# Altium schematic sheet p05_leds_switches.SchDoc
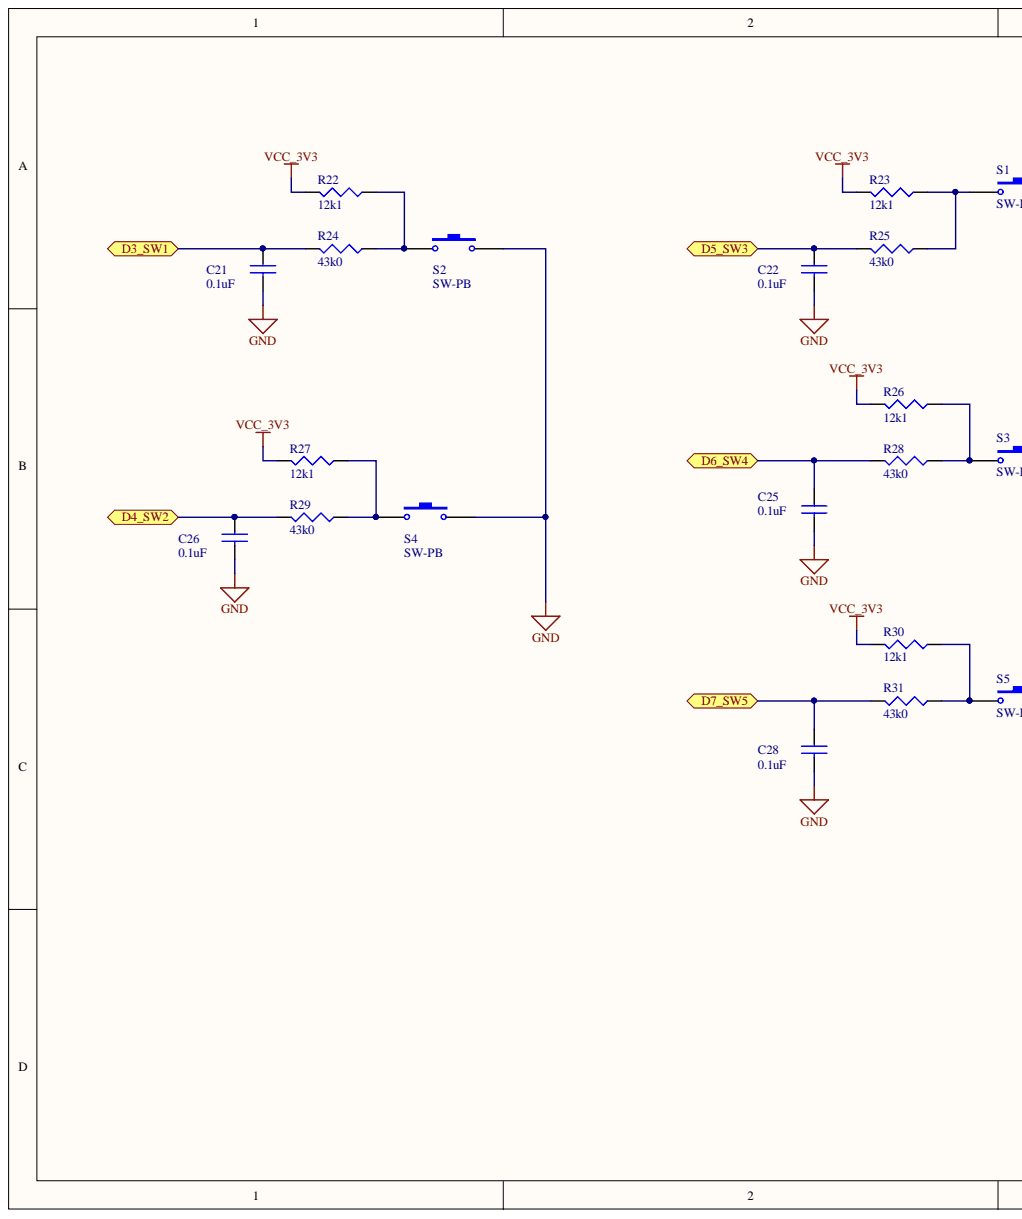
[diagram p0: p05_leds_switches.SchDoc, left]
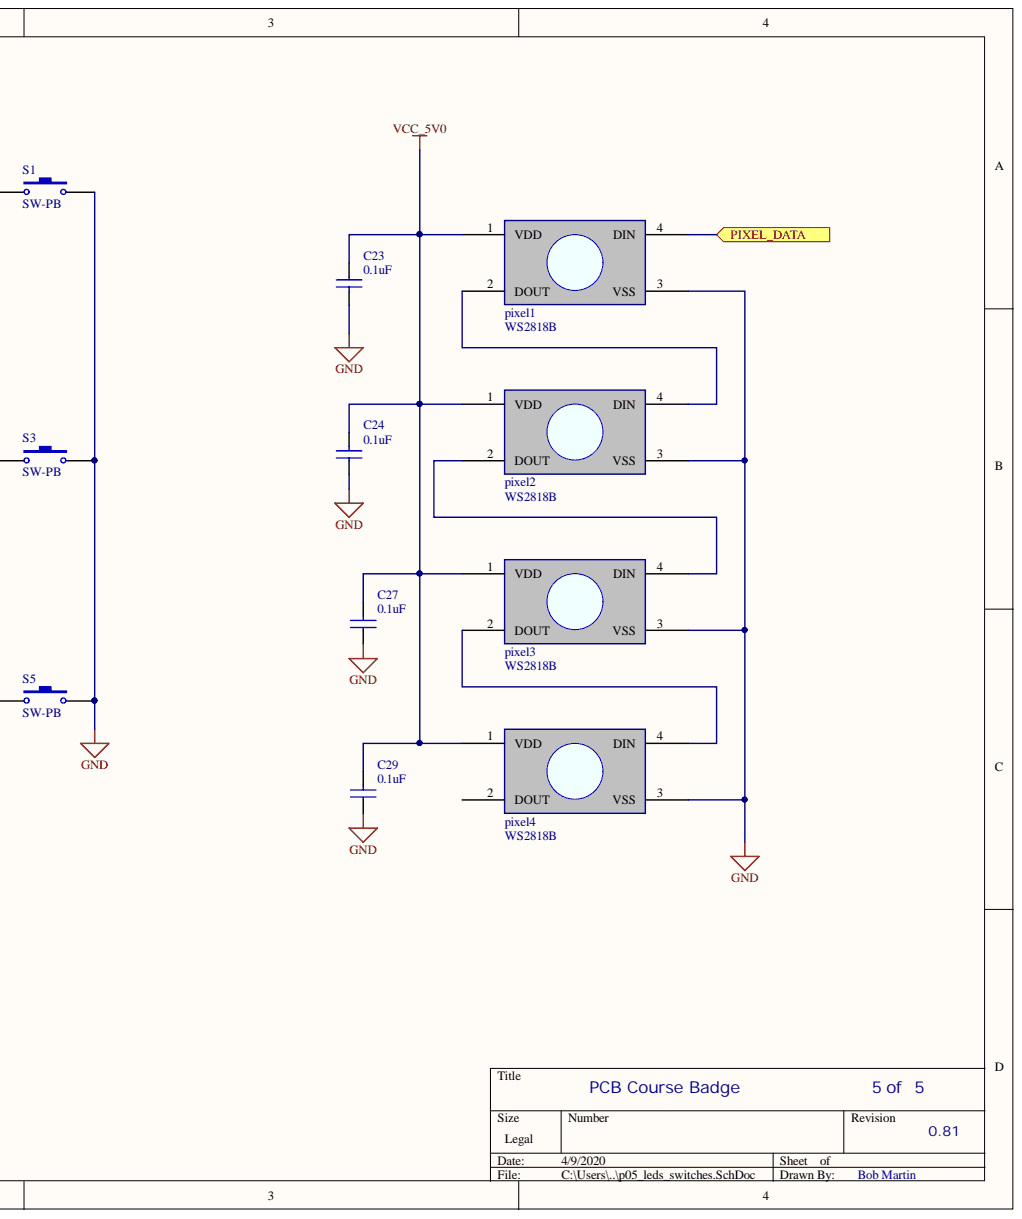
[diagram p1: p05_leds_switches.SchDoc, right]

## Parts
C21  value 0.1uF  [AP1-00039]
C22  value 0.1uF  [AP1-00039]
C23  value 0.1uF  [AP1-00039]
C24  value 0.1uF  [AP1-00039]
C25  value 0.1uF  [AP1-00039]
C26  value 0.1uF  [AP1-00039]
C27  value 0.1uF  [AP1-00039]
C28  value 0.1uF  [AP1-00039]
C29  value 0.1uF  [AP1-00039]
pixel1  WS2818B
pixel2  WS2818B
pixel3  WS2818B
pixel4  WS2818B
R22  value 12k1  [J1-0603]  (hidden comment: Res3)
R23  value 12k1  [J1-0603]  (hidden comment: Res3)
R24  value 43k0  [J1-0603]  (hidden comment: Res3)
R25  value 43k0  [J1-0603]  (hidden comment: Res3)
R26  value 12k1  [J1-0603]  (hidden comment: Res3)
R27  value 12k1  [J1-0603]  (hidden comment: Res3)
R28  value 43k0  [J1-0603]  (hidden comment: Res3)
R29  value 43k0  [J1-0603]  (hidden comment: Res3)
R30  value 12k1  [J1-0603]  (hidden comment: Res3)
R31  value 43k0  [J1-0603]  (hidden comment: Res3)
S1  SW-PB  [SPST-2]
S2  SW-PB  [SPST-2]
S3  SW-PB  [SPST-2]
S4  SW-PB  [SPST-2]
S5  SW-PB  [SPST-2]

## Ports
D3_SW1, D4_SW2, D5_SW3, D6_SW4, D7_SW5, PIXEL_DATA

## Nets
GND: C21.2 C22.2 C23.2 C24.1 C25.2 C26.2 C27.1 C28.2 C29.1 pixel1.3(VSS) pixel2.3(VSS) pixel3.3(VSS) pixel4.3(VSS) S1.2 S2.2 S3.2 S4.2 S5.2
VCC_3V3: R22.2 R23.2 R26.2 R27.2 R30.2
VCC_5V0: C23.1 C24.2 C27.2 C29.2 pixel1.1(VDD) pixel2.1(VDD) pixel3.1(VDD) pixel4.1(VDD)
D3_SW1: C21.1 R24.2 ; port D3_SW1
D4_SW2: C26.1 R29.2 ; port D4_SW2
D5_SW3: C22.1 R25.2 ; port D5_SW3
D6_SW4: C25.1 R28.2 ; port D6_SW4
D7_SW5: C28.1 R31.2 ; port D7_SW5
PIXEL_DATA: pixel1.4(DIN) ; port PIXEL_DATA
Netpixel1_2: pixel1.2(DOUT) pixel2.4(DIN)
Netpixel2_2: pixel2.2(DOUT) pixel3.4(DIN)
Netpixel3_2: pixel3.2(DOUT) pixel4.4(DIN)
NetR22_1: R22.1 R24.1 S2.1
NetR23_1: R23.1 R25.1 S1.1
NetR26_1: R26.1 R28.1 S3.1
NetR27_1: R27.1 R29.1 S4.1
NetR30_1: R30.1 R31.1 S5.1

Not connected: pixel4 2(DOUT)

## Library data of the parts
C21-C29 = A01-0461: Ceramic capacitor, SMD 0603, X5R, 10V, 10UF Â± 20%; Manufacturer: KEMET; MPN: C0603C104Z3VACTU; Database Table Name: AltiumComponents; Detail: CAP CER 0.1UF 25V Y5V 0603; Component Kind: Standard; Digi-Key PN: 399-1100-1-ND; Library Name: AltiumDbLib.DbLib
pixel1-pixel4 = neopixel: WS2812B Neopixel; Manufacturer: Worldsemi; Detail: RGB LED; Component Kind: Standard; Digi-Key PN: n/a; Library Name: badge1.SCHLIB; MPN: WS2812B
R22 R23 R26 R27 R30 = Res3: Resistor; Manufacturer: Stackpole Electronics Inc; MPN: RMCF0603FT12K1; Detail: RES 12.1K OHM 1% 1/10W 0603; Component Kind: Standard; Digi-Key PN: RMCF0603FT12K1CT-ND; Library Name: Miscellaneous Devices.IntLib; Signal Integrity: Res; Simulation: RESISTOR
R24 R25 R28 R29 R31 = Res3: Resistor; Manufacturer: Stackpole Electronics Inc; MPN: RMCF0603FT43K0; Detail: RES 43K OHM 1% 1/10W 0603; Component Kind: Standard; Digi-Key PN: RMCF0603FT43K0CT-ND; Library Name: Miscellaneous Devices.IntLib; Signal Integrity: Res; Simulation: RESISTOR
S1-S5 = SW-PB: Switch; Manufacturer: C&K; MPN: PTS645SM43SMTR92 LFS; Detail: SWITCH TACTILE SPST-NO 0.05A 12V; Value: SPST-NO; Component Kind: Standard; Digi-Key PN: CKN9112CT-ND; Library Name: Miscellaneous Devices.IntLib
